# Altium schematic sheet Input_Power.SchDoc(Input_Power)
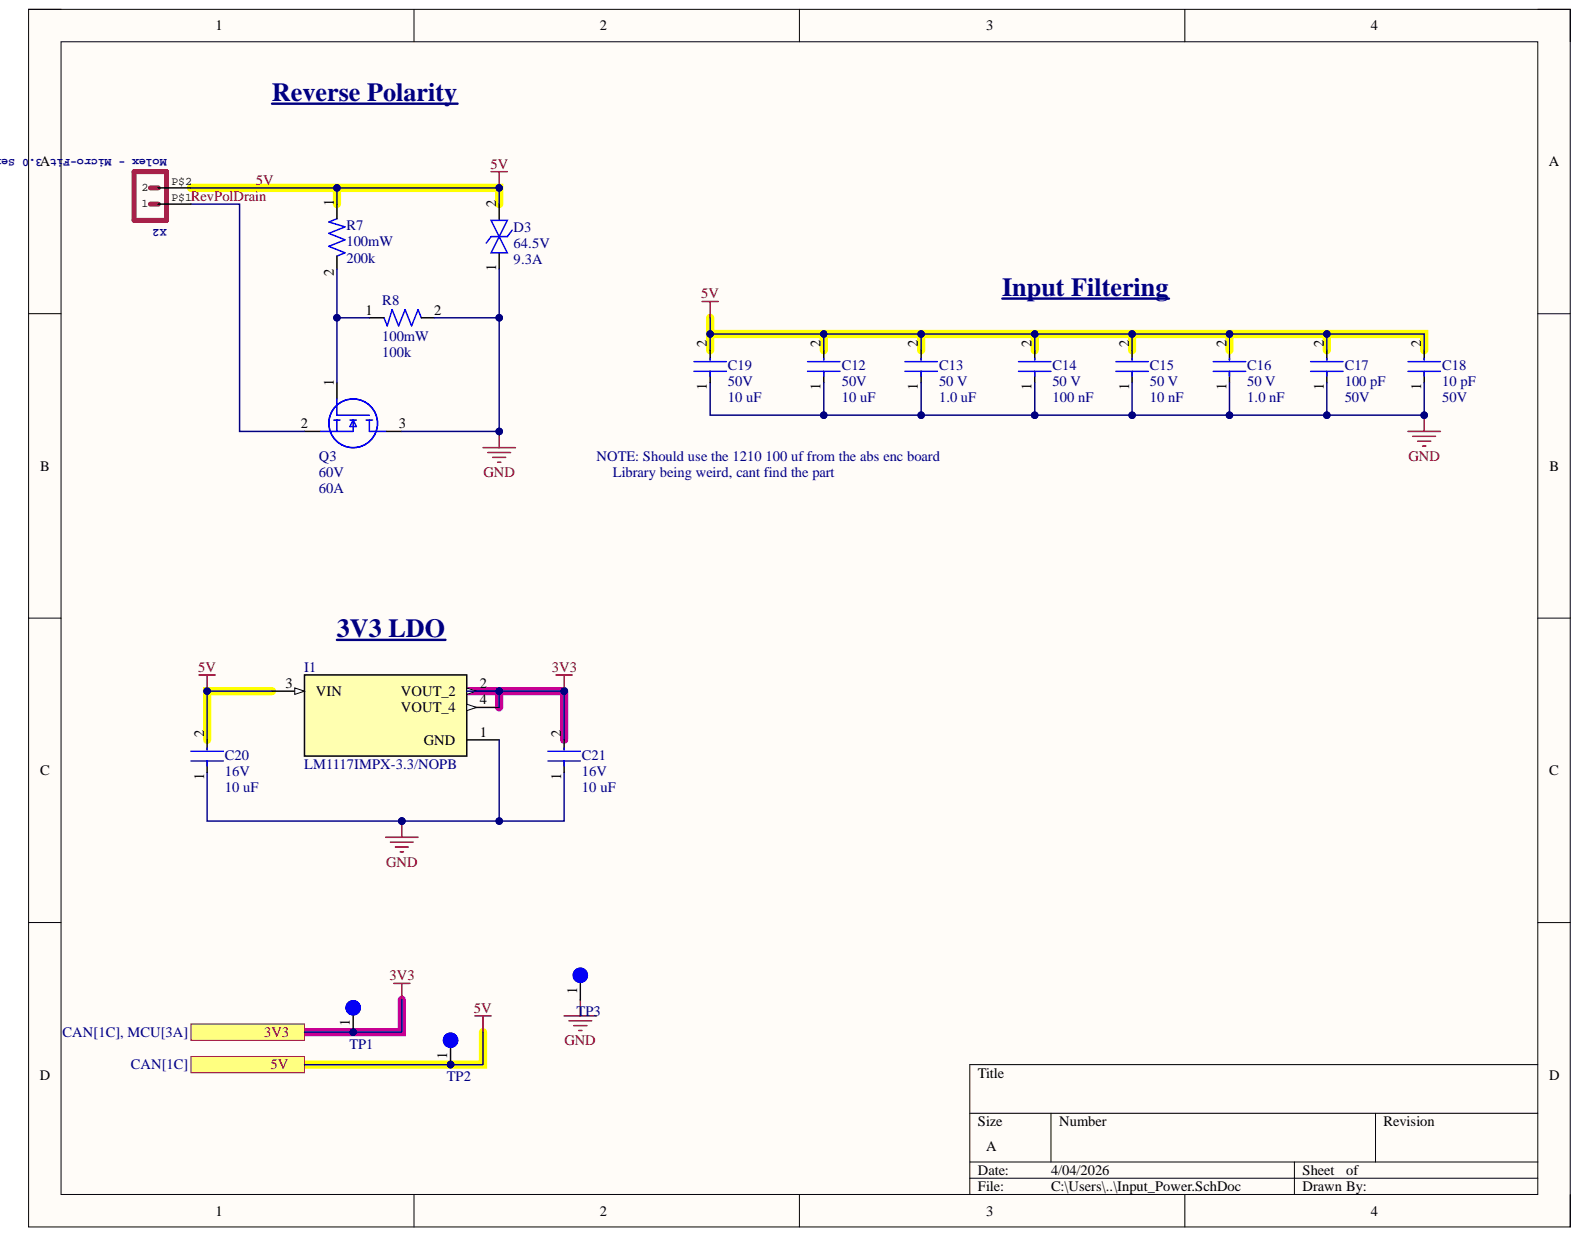
[diagram p0: Input_Power.SchDoc]

## Parts
C12  Capacitance: 10 uF  Voltage: 50V  [CAP-1210]  (hidden comment: CL32B106KBJNNNE)
C13  Capacitance: 1.0 uF  Voltage: 50 V  [CAP-0805]  (hidden comment: CC0805KKX7R9BB105)
C14  Voltage Rating: 50 V  [CAP-0805]  (hidden comment: CC0805KRX7R9BB104)
C15  Capacitance: 10 nF  Voltage: 50 V  [CAP-0603]  (hidden comment: CC0603KPX7R9BB103)
C16  Capacitance: 1.0 nF  Voltage: 50 V  [CAP-0402]  (hidden comment: CC0402KRX7R9BB102)
C17  Voltage: 50V  [CAP-0402]  (hidden comment: CC0402JRNPO9BN101)
C18  Capacitance: 10 pF  Voltage: 50V  [CAP-0402]  (hidden comment: CC0402JRNPO9BN100)
C19  Capacitance: 10 uF  Voltage: 50V  [CAP-1210]  (hidden comment: CL32B106KBJNNNE)
C20  Capacitance: 10 uF  Voltage: 16V  [CAP-1206]  (hidden comment: 293D106X9016A2TE3)
C21  Capacitance: 10 uF  Voltage: 16V  [CAP-1206]  (hidden comment: 293D106X9016A2TE3)
D3  Current Rating: 9.3A  Voltage Rating: 64.5V  [DO-214AA (SMBJ)]  (hidden comment: SMBJ6.0)
I1  LM1117IMPX-3.3/NOPB  [IC-SOT-223-4]
Q3  Voltage: 60V  [IC-8VSONCLIP]  (hidden comment: CSD18543Q3AT)
R7  Power: 100mW  Resistance: 200k  [RES-0603]  (hidden comment: RC0603FR-07200KL)
R8  Power: 100mW  Resistance: 100k  [RES-0603]  (hidden comment: RC0603FR-07100KL)
TP1  5003  [TEST-POINT-0001]  (value not drawn)
TP2  5003  [TEST-POINT-0001]  (value not drawn)
TP3  5003  [TEST-POINT-0001]  (value not drawn)
X2  Molex - Micro-Fit 3.0 Series connector,  3.00mm (0.118") pitch header, 2 positions.  [43045-0200]  (value not drawn)

## Ports
3V3, 5V

## Nets
GND: C12.1 C13.1 C14.1 C15.1 C16.1 C17.1 C18.1 C19.1 C20.1 C21.1 D3.1 I1.1(GND) Q3.3 R8.2 TP3.1
3V3: C21.2 I1.2(VOUT_2) I1.4(VOUT_4) TP1.1 ; port 3V3
5V: C12.2 C13.2 C14.2 C15.2 C16.2 C17.2 C18.2 C19.2 C20.2 D3.2 I1.3(VIN) R7.1 TP2.1 X2.P$2 ; port 5V
RevPolDrain: Q3.2 X2.P$1
NetQ3_1: Q3.1 R7.2 R8.1

## Library data of the parts
C12 C19 = CAP-10U-0002: CAP CER 10UF 50V X7R 1210; Component Kind: Standard; ComponentLink1Description: Datasheet; ComponentLink1URL: https://mm.digikey.com/Volume0/opasdata/d220001/medias/docus/41/CL32B106KBJNNNE_Spec.pdf; Device Class: Ceramic; Library Name: JLC_25.IntLib; Size: 1210
C13 = CAP-1U-0001: CAP CER 1UF 50V X7R 0805; Component Kind: Standard; ComponentLink2Description: Datasheet; ComponentLink2URL: https://www.yageo.com/upload/media/product/productsearch/datasheet/mlcc/UPY-GPHC_X7R_6.3V-to-250V_24.pdf; Device Class: Ceramic; Library Name: JLC_25.IntLib; Size: 0805
C14 = CAP-0.1U-0002: CAP CER 0.1UF 50V X7R 0805; Capacitance: 100 nF; Component Kind: Standard; ComponentLink2Description: Datasheet; ComponentLink2URL: https://www.yageo.com/upload/media/product/productsearch/datasheet/mlcc/UPY-GPHC_X7R_6.3V-to-250V_24.pdf; Device Class: Ceramic; Library Name: JLC_25.IntLib; Size: 0805
C15 = CAP-10N-0002: CAP CER 10000PF 50V X7R 0603; Component Kind: Standard; ComponentLink2Description: Datasheet; ComponentLink2URL: http://www.yageo.com/documents/recent/UPY-GPHC_X7R_6.3V-to-50V_18.pdf; Device Class: Ceramic; Library Name: JLC_25.IntLib; Size: 0603
C16 = CAP-1N-0001: CAP CER 1000PF 50V X7R 0402; Component Kind: Standard; ComponentLink2Description: Datasheet; ComponentLink2URL: http://www.yageo.com/documents/recent/UPY-GP_NP0_16V-to-50V_16.pdf; Device Class: Ceramic; Library Name: JLC_25.IntLib; Size: 0402
C17 = CAP-100P-0001: CAP CER 100PF 50V C0G/NPO 0402; Capacitance: 100 pF; Component Kind: Standard; ComponentLink1Description: Datasheet; ComponentLink1URL: https://www.yageo.com/upload/media/product/productsearch/datasheet/mlcc/UPY-GP_NP0_16V-to-50V_18.pdf; Device Class: Ceramic; Library Name: JLC_25.IntLib; Size: 0402
C18 = CAP-10P-0001: CAP CER 10PF 50V C0G/NPO 0402; Component Kind: Standard; ComponentLink1Description: Datasheet; ComponentLink1URL: https://www.yageo.com/upload/media/product/productsearch/datasheet/mlcc/UPY-GP_NP0_16V-to-50V_18.pdf; Device Class: Ceramic; Library Name: JLC_25.IntLib; Size: 0402
C20 C21 = CAP-10U-0004: CAP TANT 10UF 10% 16V 1206; Component Kind: Standard; ComponentLink1Description: Datasheet; ComponentLink1URL: https://www.lcsc.com/datasheet/lcsc_datasheet_2304140030_Vishay-Intertech-293D106X9016A2TE3_C110038.pdf; Device Class: Tantalum; Library Name: JLC_25.IntLib; Size: 1206
D3 = DIO-TVS-0001: TVS DIODE 40VWM 64.5VC DO214AA; Component Kind: Standard; ComponentLink1Description: Datasheet; ComponentLink1URL: https://www.littelfuse.com/media?resourcetype=datasheets&itemid=09a6ae9a-73cb-4ac4-acac-e6dab92ab953&filename=littelfuse_tvs_diode_smbj_datasheet.pdf; Library Name: JLC_25.IntLib
I1 = IC_LDO_3V3_0001: IC REG LIN 3.3V 800MA SOT223-4; Component Kind: Standard; ComponentLink1Description: New link; ComponentLink1URL: https://www.ti.com/lit/ds/symlink/lm1117.pdf?HQS=dis-dk-null-digikeymode-dsf-pf-null-wwe&ts=1721700739746; Library Name: JLC_25.IntLib
Q3 = NMOS-60A-0001: MOSFET N-CH 60V 12A/60A 8VSON; Continuous Current: 60A; Component Kind: Standard; ComponentLink2Description: Datasheet; ComponentLink2URL: http://www.ti.com/lit/ds/symlink/csd18543q3a.pdf; Library Name: JLC_25.IntLib
R7 = RES-200k-0001: RES SMD 200K OHM 1% 1/10W 0603; Component Kind: Standard; ComponentLink1Description: Datasheet; ComponentLink1URL: https://www.yageo.com/upload/media/product/products/datasheet/rchip/PYu-RC_Group_51_RoHS_L_12.pdf; Library Name: JLC_25.IntLib; Size: 0603; Tolerance: 1%
R8 = RES-100k-0001: RES SMD 100K OHM 1% 1/10W 0603; Component Kind: Standard; ComponentLink1Description: Datasheet; ComponentLink1URL: https://www.yageo.com/upload/media/product/products/datasheet/rchip/PYu-RC_Group_51_RoHS_L_12.pdf; Library Name: JLC_25.IntLib; Size: 0603; Tolerance: 1%
TP1-TP3 = TEST-POINT-0001: Oranange PC Test Point, Miniature Phoisphor Bronze Silver Plating Through Hole Mounting Type; Component Kind: Standard; Library Name: MasterLib.IntLib
X2 = HEADER_POS2_?43045-0200: GateName_1: G$1; Component Kind: Standard; DeviceName: 43045-0200; DeviceSetName: HEADER_POS2_?; Library Name: Molex Microfit.IntLib; LibraryName: con-molex-micro-fit-3_0_2; Package: 43045-0200; SymbolName_1: HEADER_POS2
